# Altium schematic sheet 08 - MICROHEADERS - JX1 and JX2.SchDoc("08 - MICROHEADERS - JX1 and JX2")
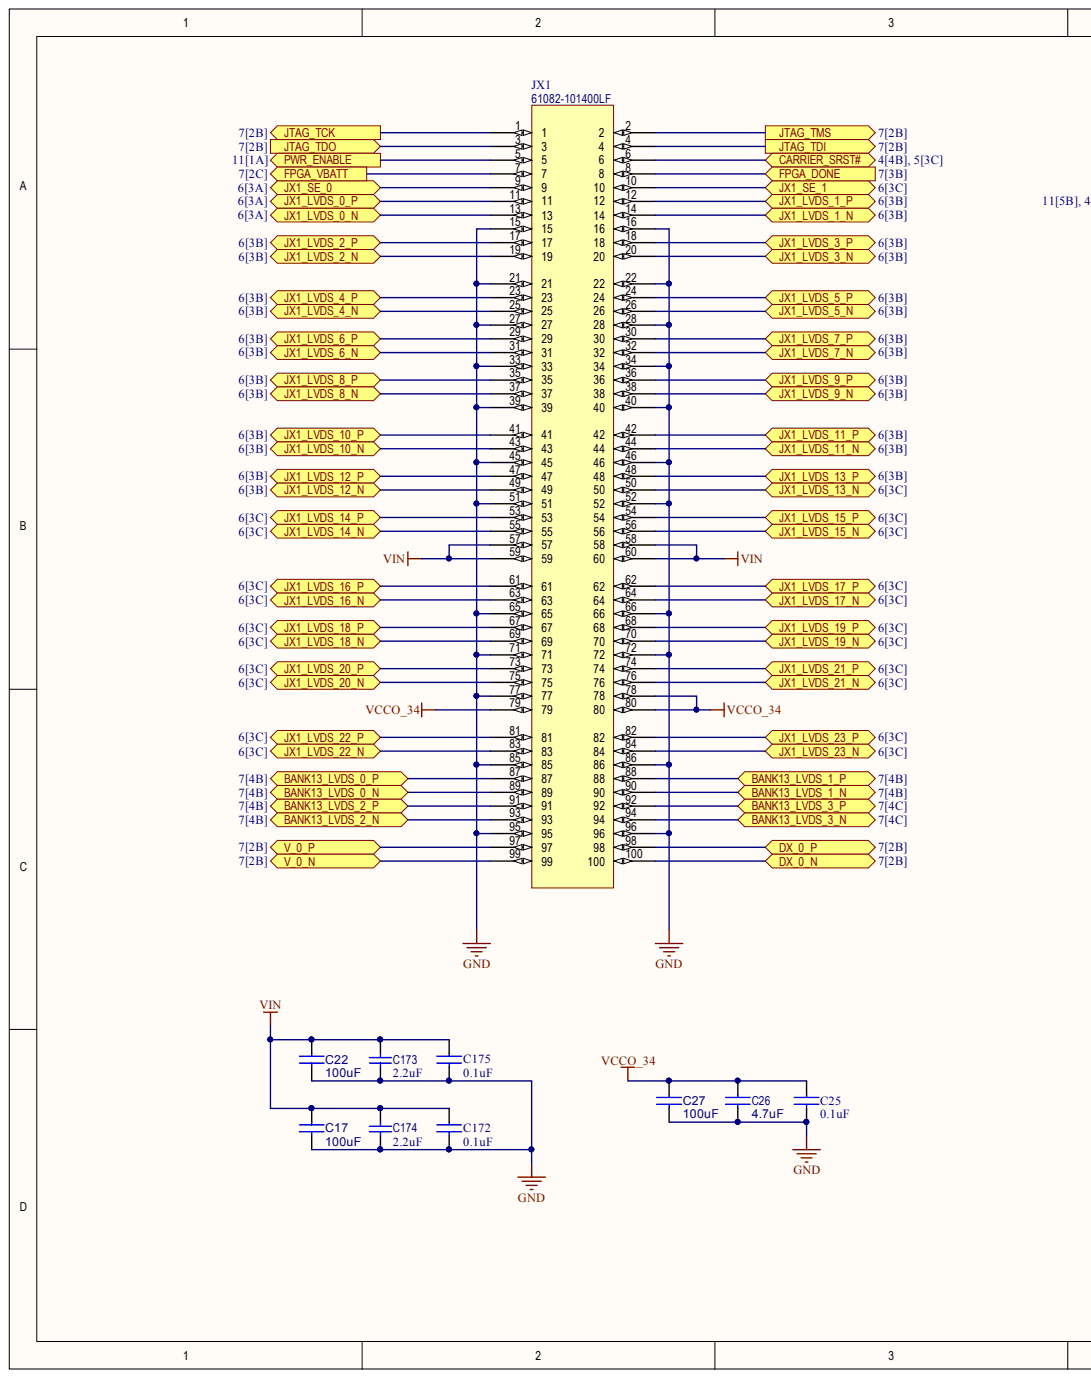
[diagram p0: 08 - MICROHEADERS - JX1 and JX2.SchDoc, left]
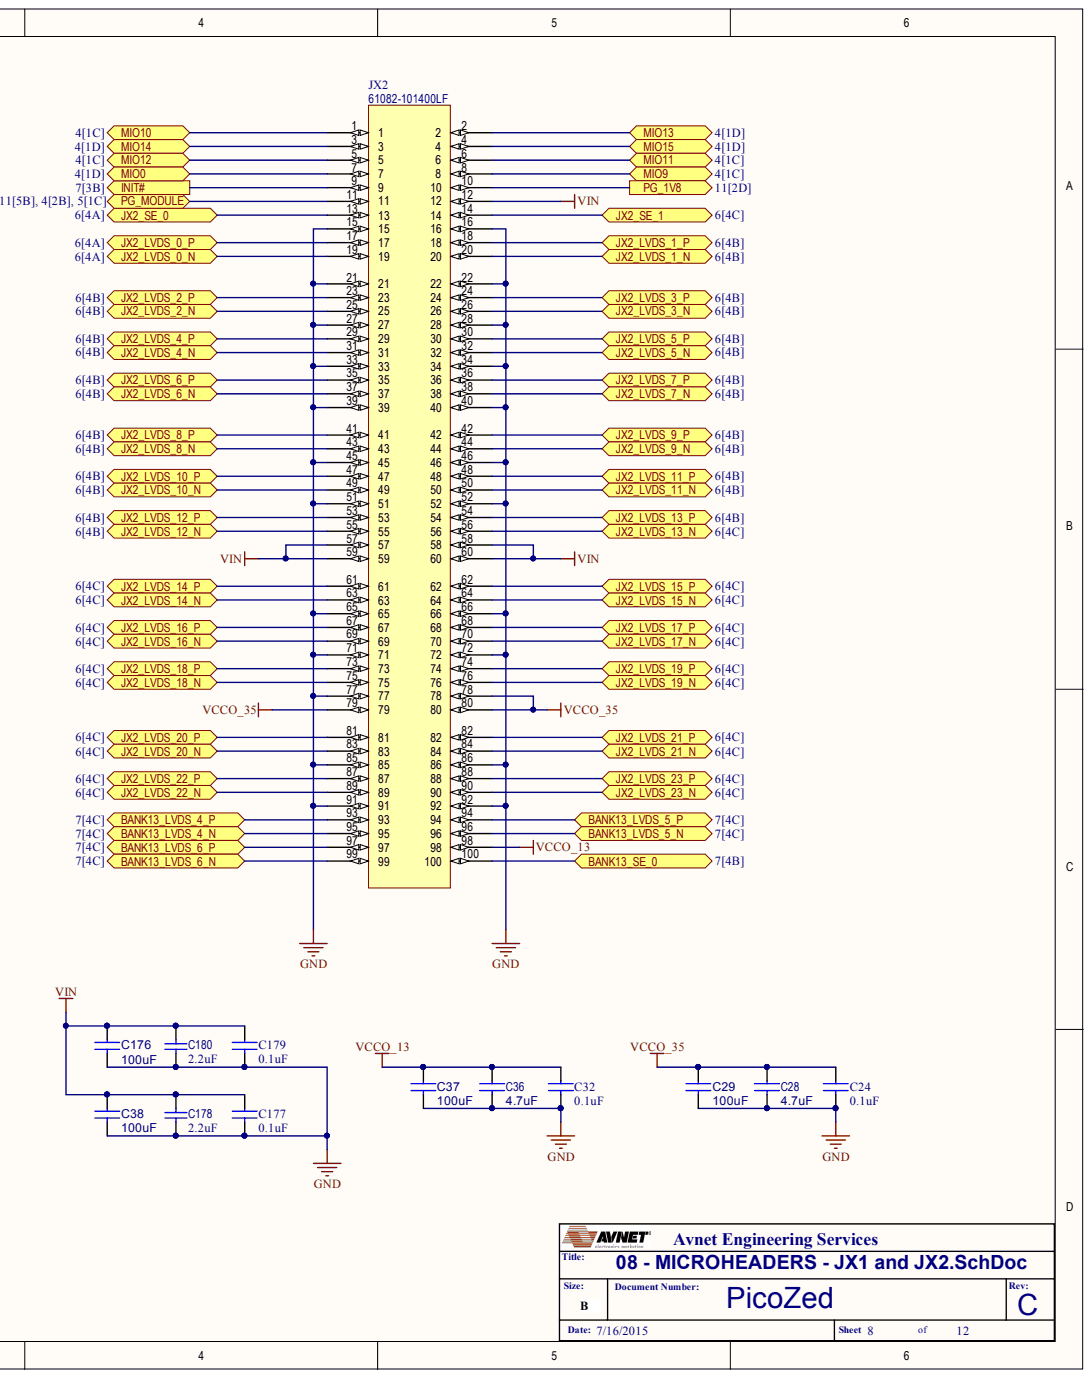
[diagram p1: 08 - MICROHEADERS - JX1 and JX2.SchDoc, right]

## Parts
C17  100uF  [C1210]
C22  100uF  [C1210]
C24  value 0.1uF  [C0201]  (hidden comment: PC522)
C25  value 0.1uF  [C0201]  (hidden comment: PC522)
C26  4.7uF  [C0402]
C27  100uF  [C1210]
C28  4.7uF  [C0402]
C29  100uF  [C1210]
C32  value 0.1uF  [C0201]  (hidden comment: PC522)
C36  4.7uF  [C0402]
C37  100uF  [C1210]
C38  100uF  [C1210]
C172  value 0.1uF  [C0201]  (hidden comment: PC522)
C173  2.2uF  [CAPC0402(1005)70_N]
C174  2.2uF  [CAPC0402(1005)70_N]
C175  value 0.1uF  [C0201]  (hidden comment: PC522)
C176  100uF  [C1210]
C177  value 0.1uF  [C0201]  (hidden comment: PC522)
C178  2.2uF  [CAPC0402(1005)70_N]
C179  value 0.1uF  [C0201]  (hidden comment: PC522)
C180  2.2uF  [CAPC0402(1005)70_N]
JX1  61082-101400LF  [61082-101400LF]
JX2  61082-101400LF  [61082-101400LF]

## Ports
BANK13_LVDS_0_N, BANK13_LVDS_0_P, BANK13_LVDS_1_N, BANK13_LVDS_1_P, BANK13_LVDS_2_N, BANK13_LVDS_2_P, BANK13_LVDS_3_N, BANK13_LVDS_3_P, BANK13_LVDS_4_N, BANK13_LVDS_4_P, BANK13_LVDS_5_N, BANK13_LVDS_5_P, BANK13_LVDS_6_N, BANK13_LVDS_6_P, BANK13_SE_0, CARRIER_SRST#, DX_0_N, DX_0_P, FPGA_DONE, FPGA_VBATT, INIT#, JTAG_TCK, JTAG_TDI, JTAG_TDO, JTAG_TMS, JX1_LVDS_0_N, JX1_LVDS_0_P, JX1_LVDS_1_N, JX1_LVDS_1_P, JX1_LVDS_2_N, JX1_LVDS_2_P, JX1_LVDS_3_N, JX1_LVDS_3_P, JX1_LVDS_4_N, JX1_LVDS_4_P, JX1_LVDS_5_N, JX1_LVDS_5_P, JX1_LVDS_6_N, JX1_LVDS_6_P, JX1_LVDS_7_N, JX1_LVDS_7_P, JX1_LVDS_8_N, JX1_LVDS_8_P, JX1_LVDS_9_N, JX1_LVDS_9_P, JX1_LVDS_10_N, JX1_LVDS_10_P, JX1_LVDS_11_N, JX1_LVDS_11_P, JX1_LVDS_12_N, JX1_LVDS_12_P, JX1_LVDS_13_N, JX1_LVDS_13_P, JX1_LVDS_14_N, JX1_LVDS_14_P, JX1_LVDS_15_N, JX1_LVDS_15_P, JX1_LVDS_16_N, JX1_LVDS_16_P, JX1_LVDS_17_N, JX1_LVDS_17_P, JX1_LVDS_18_N, JX1_LVDS_18_P, JX1_LVDS_19_N, JX1_LVDS_19_P, JX1_LVDS_20_N, JX1_LVDS_20_P, JX1_LVDS_21_N, JX1_LVDS_21_P, JX1_LVDS_22_N, JX1_LVDS_22_P, JX1_LVDS_23_N, JX1_LVDS_23_P, JX1_SE_0, JX1_SE_1, JX2_LVDS_0_N, JX2_LVDS_0_P, JX2_LVDS_1_N, JX2_LVDS_1_P, JX2_LVDS_2_N, JX2_LVDS_2_P, JX2_LVDS_3_N, JX2_LVDS_3_P, JX2_LVDS_4_N, JX2_LVDS_4_P, JX2_LVDS_5_N, JX2_LVDS_5_P, JX2_LVDS_6_N, JX2_LVDS_6_P, JX2_LVDS_7_N, JX2_LVDS_7_P, JX2_LVDS_8_N, JX2_LVDS_8_P, JX2_LVDS_9_N, JX2_LVDS_9_P, JX2_LVDS_10_N, JX2_LVDS_10_P, JX2_LVDS_11_N, JX2_LVDS_11_P, JX2_LVDS_12_N, JX2_LVDS_12_P, JX2_LVDS_13_N, JX2_LVDS_13_P, JX2_LVDS_14_N, JX2_LVDS_14_P, JX2_LVDS_15_N, JX2_LVDS_15_P, JX2_LVDS_16_N, JX2_LVDS_16_P, JX2_LVDS_17_N, JX2_LVDS_17_P, JX2_LVDS_18_N, JX2_LVDS_18_P, JX2_LVDS_19_N, JX2_LVDS_19_P, JX2_LVDS_20_N, JX2_LVDS_20_P, JX2_LVDS_21_N, JX2_LVDS_21_P, JX2_LVDS_22_N, JX2_LVDS_22_P, JX2_LVDS_23_N, JX2_LVDS_23_P, JX2_SE_0, JX2_SE_1, MIO0, MIO9, MIO10, MIO11, MIO12, MIO13, MIO14, MIO15, PG_1V8, PG_MODULE, PWR_ENABLE, V_0_N, V_0_P

## Nets
GND: C17.2 C22.2 C24.2 C25.2 C26.2 C27.2 C28.2 C29.2 C32.2 C36.2 C37.2 C38.2 C172.2 C173.1 C174.1 C175.2 C176.2 C177.2 C178.1 C179.2 C180.1 JX1.15 JX1.16 JX1.21 JX1.22 JX1.27 JX1.28 JX1.33 JX1.34 JX1.39 JX1.40 JX1.45 JX1.46 JX1.51 JX1.52 JX1.65 JX1.66 JX1.71 JX1.72 JX1.77 JX1.85 JX1.86 JX1.95 JX1.96 JX2.15 JX2.16 JX2.21 JX2.22 JX2.27 JX2.28 JX2.33 JX2.34 JX2.39 JX2.40 JX2.45 JX2.46 JX2.51 JX2.52 JX2.65 JX2.66 JX2.71 JX2.72 JX2.77 JX2.85 JX2.86 JX2.91 JX2.92
VCCO_13: C32.1 C36.1 C37.1 JX2.98
VCCO_34: C25.1 C26.1 C27.1 JX1.78 JX1.79 JX1.80
VCCO_35: C24.1 C28.1 C29.1 JX2.78 JX2.79 JX2.80
VIN: C17.1 C22.1 C38.1 C172.1 C173.2 C174.2 C175.1 C176.1 C177.1 C178.2 C179.1 C180.2 JX1.57 JX1.58 JX1.59 JX1.60 JX2.12 JX2.57 JX2.58 JX2.59 JX2.60
BANK13_LVDS_0_N: JX1.89 ; port BANK13_LVDS_0_N
BANK13_LVDS_0_P: JX1.87 ; port BANK13_LVDS_0_P
BANK13_LVDS_1_N: JX1.90 ; port BANK13_LVDS_1_N
BANK13_LVDS_1_P: JX1.88 ; port BANK13_LVDS_1_P
BANK13_LVDS_2_N: JX1.93 ; port BANK13_LVDS_2_N
BANK13_LVDS_2_P: JX1.91 ; port BANK13_LVDS_2_P
BANK13_LVDS_3_N: JX1.94 ; port BANK13_LVDS_3_N
BANK13_LVDS_3_P: JX1.92 ; port BANK13_LVDS_3_P
BANK13_LVDS_4_N: JX2.95 ; port BANK13_LVDS_4_N
BANK13_LVDS_4_P: JX2.93 ; port BANK13_LVDS_4_P
BANK13_LVDS_5_N: JX2.96 ; port BANK13_LVDS_5_N
BANK13_LVDS_5_P: JX2.94 ; port BANK13_LVDS_5_P
BANK13_LVDS_6_N: JX2.99 ; port BANK13_LVDS_6_N
BANK13_LVDS_6_P: JX2.97 ; port BANK13_LVDS_6_P
BANK13_SE_0: JX2.100 ; port BANK13_SE_0
CARRIER_SRST#: JX1.6 ; port CARRIER_SRST#
DX_0_N: JX1.100 ; port DX_0_N
DX_0_P: JX1.98 ; port DX_0_P
FPGA_DONE: JX1.8 ; port FPGA_DONE
FPGA_VBATT: JX1.7 ; port FPGA_VBATT
INIT#: JX2.9 ; port INIT#
JTAG_TCK: JX1.1 ; port JTAG_TCK
JTAG_TDI: JX1.4 ; port JTAG_TDI
JTAG_TDO: JX1.3 ; port JTAG_TDO
JTAG_TMS: JX1.2 ; port JTAG_TMS
JX1_LVDS_0_N: JX1.13 ; port JX1_LVDS_0_N
JX1_LVDS_0_P: JX1.11 ; port JX1_LVDS_0_P
JX1_LVDS_1_N: JX1.14 ; port JX1_LVDS_1_N
JX1_LVDS_1_P: JX1.12 ; port JX1_LVDS_1_P
JX1_LVDS_2_N: JX1.19 ; port JX1_LVDS_2_N
JX1_LVDS_2_P: JX1.17 ; port JX1_LVDS_2_P
JX1_LVDS_3_N: JX1.20 ; port JX1_LVDS_3_N
JX1_LVDS_3_P: JX1.18 ; port JX1_LVDS_3_P
JX1_LVDS_4_N: JX1.25 ; port JX1_LVDS_4_N
JX1_LVDS_4_P: JX1.23 ; port JX1_LVDS_4_P
JX1_LVDS_5_N: JX1.26 ; port JX1_LVDS_5_N
JX1_LVDS_5_P: JX1.24 ; port JX1_LVDS_5_P
JX1_LVDS_6_N: JX1.31 ; port JX1_LVDS_6_N
JX1_LVDS_6_P: JX1.29 ; port JX1_LVDS_6_P
JX1_LVDS_7_N: JX1.32 ; port JX1_LVDS_7_N
JX1_LVDS_7_P: JX1.30 ; port JX1_LVDS_7_P
JX1_LVDS_8_N: JX1.37 ; port JX1_LVDS_8_N
JX1_LVDS_8_P: JX1.35 ; port JX1_LVDS_8_P
JX1_LVDS_9_N: JX1.38 ; port JX1_LVDS_9_N
JX1_LVDS_9_P: JX1.36 ; port JX1_LVDS_9_P
JX1_LVDS_10_N: JX1.43 ; port JX1_LVDS_10_N
JX1_LVDS_10_P: JX1.41 ; port JX1_LVDS_10_P
JX1_LVDS_11_N: JX1.44 ; port JX1_LVDS_11_N
JX1_LVDS_11_P: JX1.42 ; port JX1_LVDS_11_P
JX1_LVDS_12_N: JX1.49 ; port JX1_LVDS_12_N
JX1_LVDS_12_P: JX1.47 ; port JX1_LVDS_12_P
JX1_LVDS_13_N: JX1.50 ; port JX1_LVDS_13_N
JX1_LVDS_13_P: JX1.48 ; port JX1_LVDS_13_P
JX1_LVDS_14_N: JX1.55 ; port JX1_LVDS_14_N
JX1_LVDS_14_P: JX1.53 ; port JX1_LVDS_14_P
JX1_LVDS_15_N: JX1.56 ; port JX1_LVDS_15_N
JX1_LVDS_15_P: JX1.54 ; port JX1_LVDS_15_P
JX1_LVDS_16_N: JX1.63 ; port JX1_LVDS_16_N
JX1_LVDS_16_P: JX1.61 ; port JX1_LVDS_16_P
JX1_LVDS_17_N: JX1.64 ; port JX1_LVDS_17_N
JX1_LVDS_17_P: JX1.62 ; port JX1_LVDS_17_P
JX1_LVDS_18_N: JX1.69 ; port JX1_LVDS_18_N
JX1_LVDS_18_P: JX1.67 ; port JX1_LVDS_18_P
JX1_LVDS_19_N: JX1.70 ; port JX1_LVDS_19_N
JX1_LVDS_19_P: JX1.68 ; port JX1_LVDS_19_P
JX1_LVDS_20_N: JX1.75 ; port JX1_LVDS_20_N
JX1_LVDS_20_P: JX1.73 ; port JX1_LVDS_20_P
JX1_LVDS_21_N: JX1.76 ; port JX1_LVDS_21_N
JX1_LVDS_21_P: JX1.74 ; port JX1_LVDS_21_P
JX1_LVDS_22_N: JX1.83 ; port JX1_LVDS_22_N
JX1_LVDS_22_P: JX1.81 ; port JX1_LVDS_22_P
JX1_LVDS_23_N: JX1.84 ; port JX1_LVDS_23_N
JX1_LVDS_23_P: JX1.82 ; port JX1_LVDS_23_P
JX1_SE_0: JX1.9 ; port JX1_SE_0
JX1_SE_1: JX1.10 ; port JX1_SE_1
JX2_LVDS_0_N: JX2.19 ; port JX2_LVDS_0_N
JX2_LVDS_0_P: JX2.17 ; port JX2_LVDS_0_P
JX2_LVDS_1_N: JX2.20 ; port JX2_LVDS_1_N
JX2_LVDS_1_P: JX2.18 ; port JX2_LVDS_1_P
JX2_LVDS_2_N: JX2.25 ; port JX2_LVDS_2_N
JX2_LVDS_2_P: JX2.23 ; port JX2_LVDS_2_P
JX2_LVDS_3_N: JX2.26 ; port JX2_LVDS_3_N
JX2_LVDS_3_P: JX2.24 ; port JX2_LVDS_3_P
JX2_LVDS_4_N: JX2.31 ; port JX2_LVDS_4_N
JX2_LVDS_4_P: JX2.29 ; port JX2_LVDS_4_P
JX2_LVDS_5_N: JX2.32 ; port JX2_LVDS_5_N
JX2_LVDS_5_P: JX2.30 ; port JX2_LVDS_5_P
JX2_LVDS_6_N: JX2.37 ; port JX2_LVDS_6_N
JX2_LVDS_6_P: JX2.35 ; port JX2_LVDS_6_P
JX2_LVDS_7_N: JX2.38 ; port JX2_LVDS_7_N
JX2_LVDS_7_P: JX2.36 ; port JX2_LVDS_7_P
JX2_LVDS_8_N: JX2.43 ; port JX2_LVDS_8_N
JX2_LVDS_8_P: JX2.41 ; port JX2_LVDS_8_P
JX2_LVDS_9_N: JX2.44 ; port JX2_LVDS_9_N
JX2_LVDS_9_P: JX2.42 ; port JX2_LVDS_9_P
JX2_LVDS_10_N: JX2.49 ; port JX2_LVDS_10_N
JX2_LVDS_10_P: JX2.47 ; port JX2_LVDS_10_P
JX2_LVDS_11_N: JX2.50 ; port JX2_LVDS_11_N
JX2_LVDS_11_P: JX2.48 ; port JX2_LVDS_11_P
JX2_LVDS_12_N: JX2.55 ; port JX2_LVDS_12_N
JX2_LVDS_12_P: JX2.53 ; port JX2_LVDS_12_P
JX2_LVDS_13_N: JX2.56 ; port JX2_LVDS_13_N
JX2_LVDS_13_P: JX2.54 ; port JX2_LVDS_13_P
JX2_LVDS_14_N: JX2.63 ; port JX2_LVDS_14_N
JX2_LVDS_14_P: JX2.61 ; port JX2_LVDS_14_P
JX2_LVDS_15_N: JX2.64 ; port JX2_LVDS_15_N
JX2_LVDS_15_P: JX2.62 ; port JX2_LVDS_15_P
JX2_LVDS_16_N: JX2.69 ; port JX2_LVDS_16_N
JX2_LVDS_16_P: JX2.67 ; port JX2_LVDS_16_P
JX2_LVDS_17_N: JX2.70 ; port JX2_LVDS_17_N
JX2_LVDS_17_P: JX2.68 ; port JX2_LVDS_17_P
JX2_LVDS_18_N: JX2.75 ; port JX2_LVDS_18_N
JX2_LVDS_18_P: JX2.73 ; port JX2_LVDS_18_P
JX2_LVDS_19_N: JX2.76 ; port JX2_LVDS_19_N
JX2_LVDS_19_P: JX2.74 ; port JX2_LVDS_19_P
JX2_LVDS_20_N: JX2.83 ; port JX2_LVDS_20_N
JX2_LVDS_20_P: JX2.81 ; port JX2_LVDS_20_P
JX2_LVDS_21_N: JX2.84 ; port JX2_LVDS_21_N
JX2_LVDS_21_P: JX2.82 ; port JX2_LVDS_21_P
JX2_LVDS_22_N: JX2.89 ; port JX2_LVDS_22_N
JX2_LVDS_22_P: JX2.87 ; port JX2_LVDS_22_P
JX2_LVDS_23_N: JX2.90 ; port JX2_LVDS_23_N
JX2_LVDS_23_P: JX2.88 ; port JX2_LVDS_23_P
JX2_SE_0: JX2.13 ; port JX2_SE_0
JX2_SE_1: JX2.14 ; port JX2_SE_1
MIO0: JX2.7 ; port MIO0
MIO9: JX2.8 ; port MIO9
MIO10: JX2.1 ; port MIO10
MIO11: JX2.6 ; port MIO11
MIO12: JX2.5 ; port MIO12
MIO13: JX2.2 ; port MIO13
MIO14: JX2.3 ; port MIO14
MIO15: JX2.4 ; port MIO15
PG_1V8: JX2.10 ; port PG_1V8
PG_MODULE: JX2.11 ; port PG_MODULE
PWR_ENABLE: JX1.5 ; port PWR_ENABLE
V_0_N: JX1.99 ; port V_0_N
V_0_P: JX1.97 ; port V_0_P

## Library data of the parts
C17 C22 C27 C29 C37 C38 C176 = AVPC-0000209: CAP SM 100uF 6.3V 20% X5R C1210; PartCode: PC; AsyTxt: PC209; Database Table Name: Passive-Cap$; MFG Code: MUR; MFGPN: GRM32EF50J107ZE20K; Power: 0; Availability: 180K-AV; AVLNo: AVPC-0000209; CLASSNAME: DDR_TERM; Component Kind: Standard; ComponentLink1Description: Datasheet; Cost: .41; Device: CAP; Dielectric: X5R; PkgType: SM; Received: 0; RoHS: Yes; Size: 9.11; Status: Preliminary; Tol: 20%; Value: 100uF; Volt: 6.3V; ComponentLink1URL: C:\AVDBLIB\DATASHEETS\GRM31CR60J107ME39.pdf; Library Name: Avnet_DBlib.DbLib
C24 C25 C32 C172 C175 C177 C179 = AVPC-0000522: CAP SM 0.1uF 10V 10% X5R C0201; PartCode: PC; Database Table Name: Passive-Cap$; MFG Code: AVX; MFGPN: 02016D104KAT2A; AsyTxt: PC522; AVLNo: AVPC-0000522; CLASSNAME: U11_BYP; Component Kind: Standard; ComponentLink1Description: Datasheet; Device: CAP; Dielectric: X5R; PkgType: SM; RoHS: Yes; Status: Preliminary; Tol: 10%; Volt: 10V; ComponentLink1URL: C:\AVDBLIB\DATASHEETS\AVX_CCOG.pdf; Library Name: Avnet_DBlib.DbLib
C26 C28 C36 = AVPC-0000499: CAP SM 4.7uF 6.3V 20% X5R C0402; PartCode: PC; AsyTxt: PC499; Database Table Name: Passive-Cap$; MFG Code: AVX; MFGPN: GRM155R60J475ME87D; Power: 0; Availability: 140K-DK; AVLNo: AVPC-0000499; Component Kind: Standard; ComponentLink1Description: Datasheet; Cost: .46; Device: CAP; Dielectric: X5R; PkgType: SM; Received: 0; RoHS: Yes; Size: 1.9; Status: Preliminary; Tol: 20%; Value: 4.7uF; Volt: 6.3V; ComponentLink1URL: C:\AVDBLIB\DATASHEETS\murata_caps_c02e.pdf; Library Name: Avnet_DBlib.DbLib
C173 C174 C178 C180 = AVPC-0000161,A-0: CAP CER 2.2UF 25V 20% X5R 0402; Material: CERAMIC; MFG 1: TDK; MFGPN 1: C1005X5R1E225M050BC; Mount Type (SM/TH): SM; Numeric Value (R=Ω, C=uF, L=uH, FB=Ω ): 2.2; Polarized (Y/N): N; Preferrence Code: A; Temp-Range: -55 to 85C; AES PN: AVPC-0000161; Component Kind: Standard; Component Type: CAP; Dielectric Type: X5R; MFG 1 Datasheet link: http://www.tdk.com/pdf/TDKMLCCCapRange.pdf; Package: 0402 (1005 Metric); Rated Voltage: 25V; RoHS: Y; Tolerance: 20%; Value: 2.2uF; Library Name: AES Altium Vault
JX1 JX2 = 61082-101400LF: Microheader 100 pos Plug .8mm; AVLNo: AVEH-0000140; MFG Code: FCI; Component Kind: Standard; Library Name: Zynq_Z010_ZedStick.SchLib; MFGPN: 61082-101400LF
